# Altium schematic sheet LV_Bat_Current_Sense.SchDoc
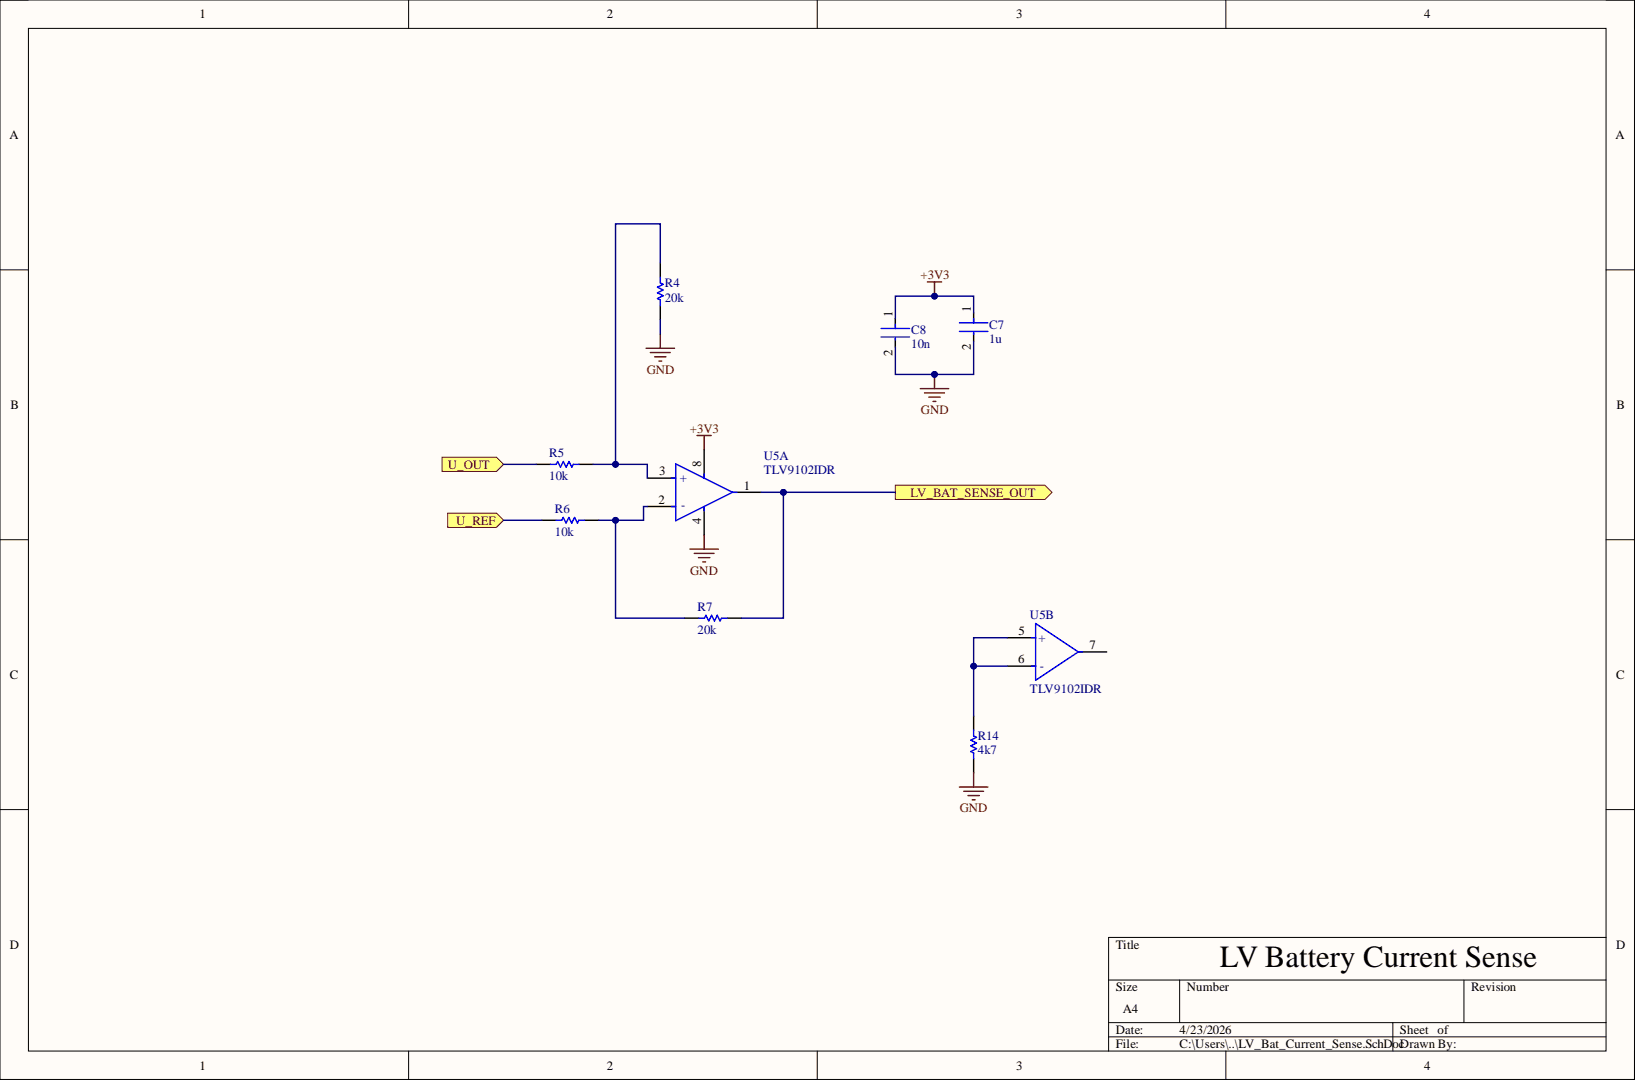
[diagram p0: LV_Bat_Current_Sense.SchDoc]

## Parts
C7  1u  [FP-0603-L_1_6_0_15-W_0_8-IPC_B]
C8  10n  [FP-0603-L_1_6_0_15-W_0_8-IPC_B]
R4  20k  [RESC1608X55X30ML15T15]
R5  10k  [RESC1608X55X30ML15T15]
R6  10k  [RESC1608X55X30ML15T15]
R7  20k  [RESC1608X55X30ML15T15]
R14  4k7  [RESC1608X55X30ML15T15]
U5  TLV9102IDR  [FP-D0008A-IPC_B]  (parts A,B)

## Ports
LV_BAT_SENSE_OUT, U_OUT, U_REF

## Nets
+3V3: C7.1 C8.1 U5.8
GND: C7.2 C8.2 R4.1 R14.2 U5.4
LV_BAT_SENSE_OUT: R7.1 U5.1 ; port LV_BAT_SENSE_OUT
U_OUT: R5.2 ; port U_OUT
U_REF: R6.2 ; port U_REF
NetR4_2: R4.2 R5.1 U5.3(+)
NetR6_1: R6.1 R7.2 U5.2(-)
NetR14_1: R14.1 U5.5(+) U5.6(-)
NetU5_7: U5.7

## Library data of the parts
C7 C8 = CMP-2000-07531-4: Ceramic Capacitor General Use 1uF ±10% 50V X7R 0603; Manufacturer: Taiyo Yuden; Manufacturer Part Number: UMK107AB7105KA-T; Case Code (Imperial): 0603; Case Code (Metric): 1608; Case/Package: 0603; Component Kind: Standard; Construction: Flat; Depth: 0.8mm; Dielectric: X7R; Halogen Free: Halogen Free; Height: 0.8mm; Length: 1.6mm; Max Operating Temperature: 125°C; Min Operating Temperature: -55°C; Mount: Surface Mount; Package Quantity: 1; Packaging: Tape and Reel; Radiation Hardening: No; RoHS Compliant: Yes; Series: M; Termination: SMD/SMT; Thickness: 0.037inch; Tolerance: 10%; Voltage Rating: 50V; Voltage Rating (DC): 50V; Width: 0.031inch; Library Name: ePDM_V0.0.SCHLIB
  C7: Capacitance: 1uF
  C8: Capacitance: 10n
R4-R7 R14 = CMP-1012-00320-3: Chip Resistor, 22 Ohm, +/- 1%, 0.1 W, -55 to 155 degC, 0603 (1608 Metric), RoHS, Tape and Reel; Component Kind: Standard; ComponentLink1Description: Manufacturer URL; ComponentLink1URL: http://www.panasonic.com/; ComponentLink2Description: Datasheet; ComponentLink2URL: http://industrial.panasonic.com/cdbs/www-data/pdf/RDA0000/AOA0000C86.pdf; DatasheetVersion: Apr-15; Library Name: Altium Content Vault; PackageDescription: 2-Pin Surface Mount Device, Body 1.6 x 0.85 mm; PackageReference: 0603
U5 = CMP-04895-000235-1: IC OPAMP POWER 2 CIRCUIT 8SOIC; Component Kind: Standard; Library Name: Altium Content Vault
